# Altium schematic sheet BluePill.SchDoc
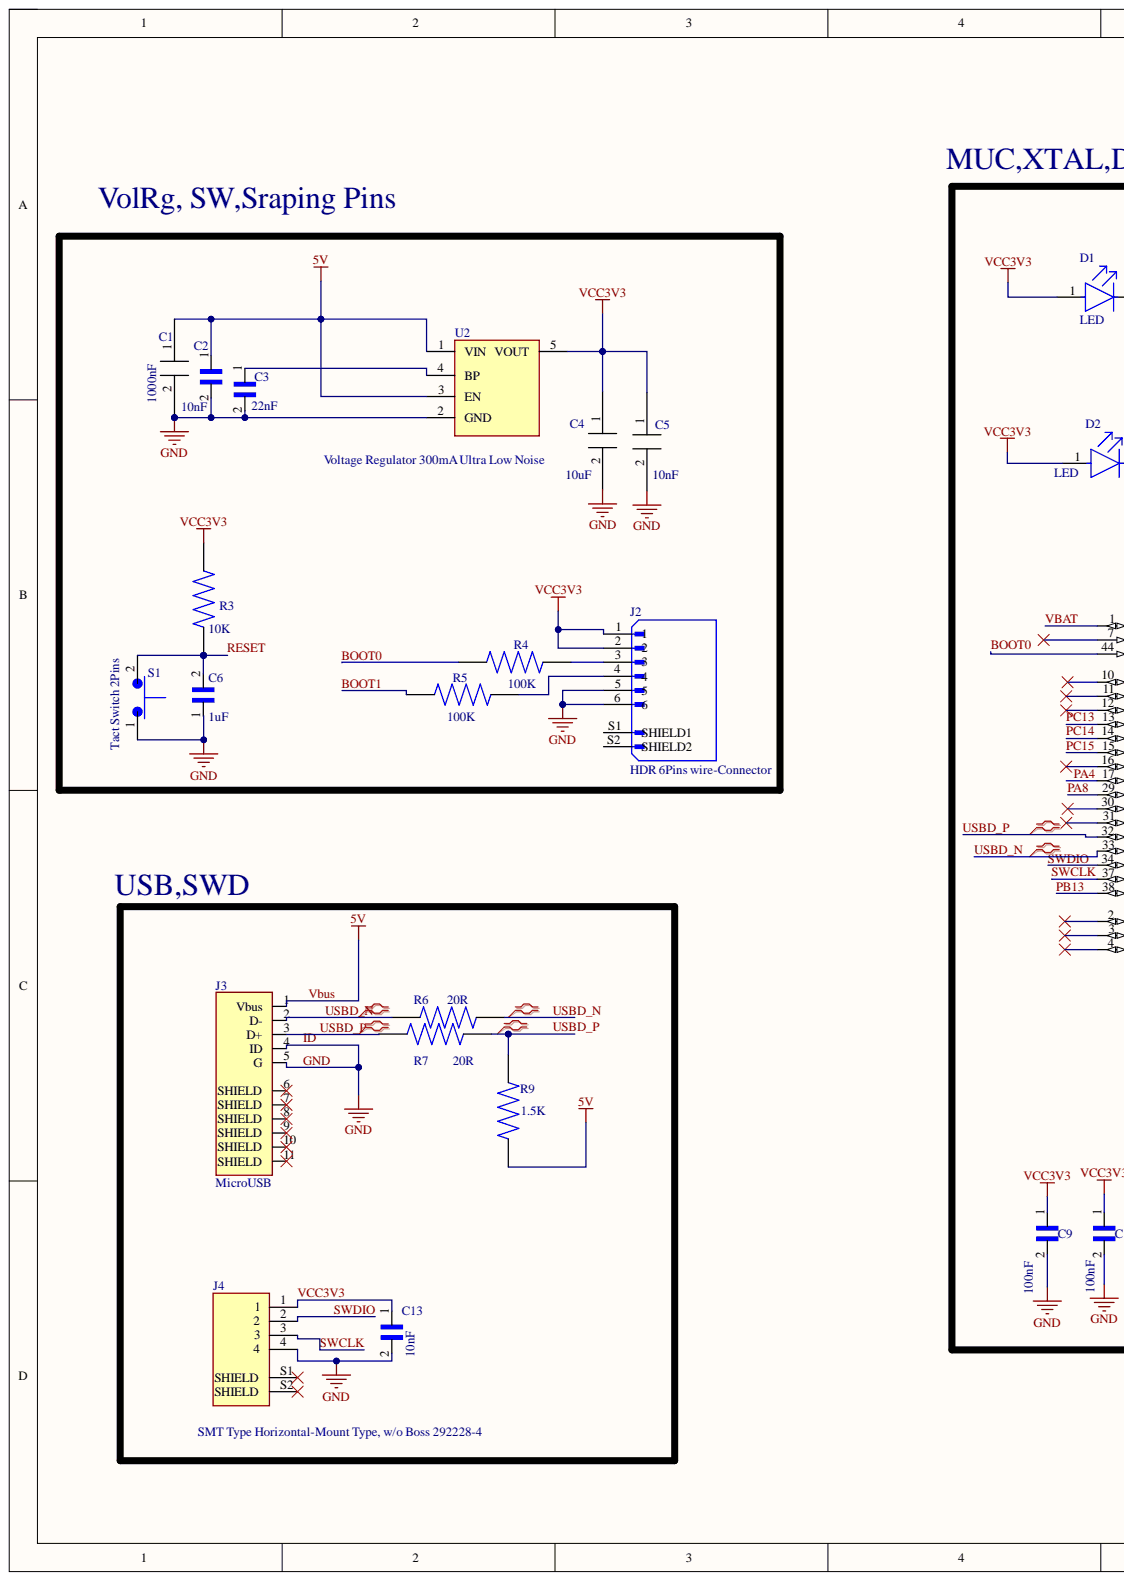
[diagram p0: BluePill.SchDoc, left]
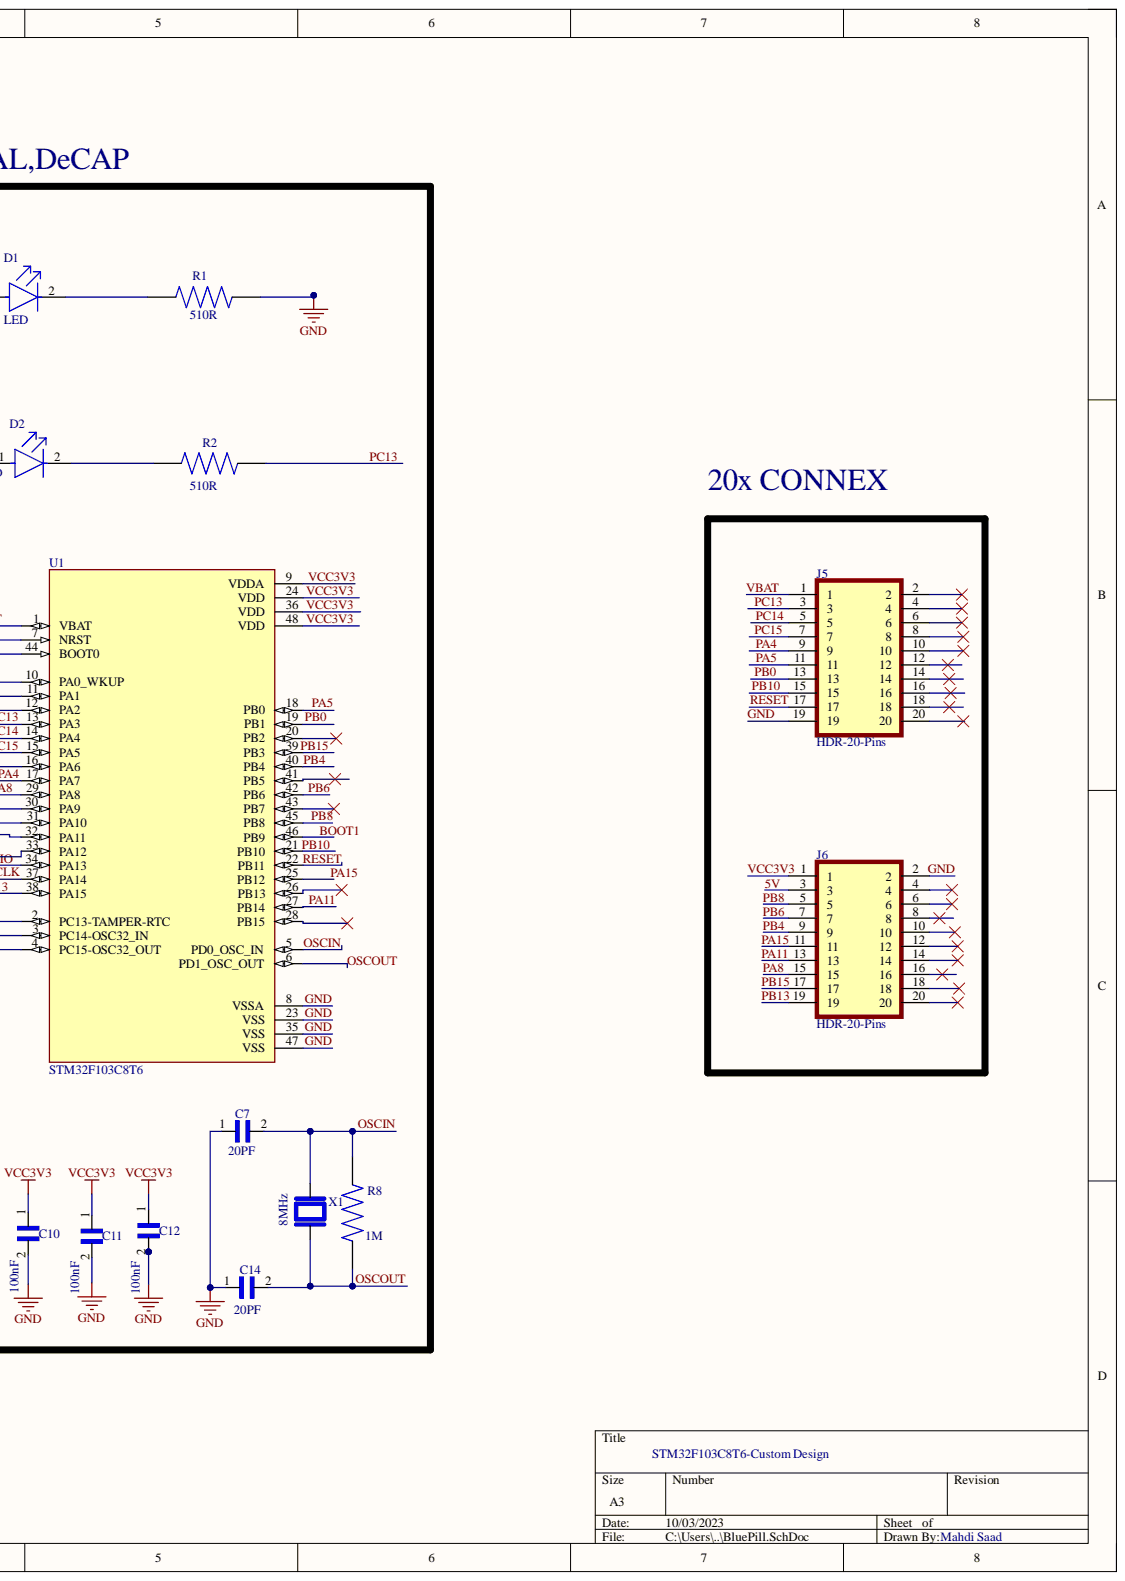
[diagram p1: BluePill.SchDoc, right]

## Parts
C1  1000nF  [Capacitor]
C2  10nF  [CAP_0603_Generic]
C3  22nF  [CAP_0603_Generic]
C4  10uF  [Capacitor]
C5  10nF  [Capacitor]
C6  1uF  [CAP_0603_Generic]
C7  20PF  [CAP_0603_Generic]
C9  100nF  [CAP_0603_Generic]
C10  100nF  [CAP_0603_Generic]
C11  100nF  [CAP_0603_Generic]
C12  100nF  [CAP_0603_Generic]
C13  10nF  [CAP_0603_Generic]
C14  20PF  [CAP_0603_Generic]
D1  LED  [LED 0508 Green SMT]
D2  LED  [LED 0508 Green SMT]
J2  HDR 6Pins wire-Connector  [6Pins HDR Wire-to-Board]
J3  MicroUSB  [MicroUSB]
J4  SMT Type Horizontal-Mount Type, w/o Boss 292228-4  [SMT 4 Pins Connector]
J5  HDR-20-Pins  [20Pins HDR]
J6  HDR-20-Pins  [20Pins HDR]
R1  510R  [Resisitor 0603 20 OHM]
R2  510R  [Resisitor 0603 20 OHM]
R3  10K  [Resisitor 0603 20 OHM]
R4  100K  [Resisitor 0603 20 OHM]
R5  100K  [Resisitor 0603 20 OHM]
R6  20R  [Resisitor 0603 20 OHM]
R7  20R  [Resisitor 0603 20 OHM]
R8  1M  [Capacitor]
R9  1.5K  [Resisitor 0603 20 OHM]
S1  Tact Switch 2Pins  [Tact Switch 2Pins]
U1  STM32F103C8T6  [STM32-New]
U2  Voltage Regulator 300mA Ultra Low Noise  [RT9193 Voltage Regulator]
X1  8MHz  [Crystal OSC 8Mhz]

## Nets
5V: C1.1 C2.1 J3.1(Vbus) J6.3 R9.1 U2.1(VIN) U2.3(EN)
BOOT0: R4.1 U1.44(BOOT0)
BOOT1: R5.1 U1.46(PB9)
GND: C1.2 C2.2 C3.2 C4.2 C5.2 C6.1 C7.1 C9.2 C10.2 C11.2 C12.2 C13.2 C14.1 J2.5 J2.6 J3.4(ID) J3.5(G) J4.4 J5.19 J6.2 R1.2 S1.1 U1.8(VSSA) U1.23(VSS) U1.35(VSS) U1.47(VSS) U2.2(GND)
OSCIN: C7.2 R8.2 U1.5(PD0_OSC_IN) X1.2
OSCOUT: C14.2 R8.1 U1.6(PD1_OSC_OUT) X1.1
PA4: J5.9 U1.17(PA7)
PA5: J5.11 U1.18(PB0)
PA8: J6.15 U1.29(PA8)
PA11: J6.13 U1.27(PB14)
PA15: J6.11 U1.25(PB12)
PB0: J5.13 U1.19(PB1)
PB4: J6.9 U1.40(PB4)
PB6: J6.7 U1.42(PB6)
PB8: J6.5 U1.45(PB8)
PB10: J5.15 U1.21(PB10)
PB13: J6.19 U1.38(PA15)
PB15: J6.17 U1.39(PB3)
PC13: J5.3 R2.2 U1.13(PA3)
PC14: J5.5 U1.14(PA4)
PC15: J5.7 U1.15(PA5)
RESET: C6.2 J5.17 R3.1 S1.2 U1.22(PB11)
SWCLK: J4.3 U1.37(PA14)
SWDIO: J4.2 U1.34(PA13)
USBD_N: J3.2(D-) R6.1 R6.2 U1.33(PA12)
USBD_P: J3.3(D+) R7.1 R7.2 R9.2 U1.32(PA11)
VBAT: J5.1 U1.1(VBAT)
VCC3V3: C4.1 C5.1 C9.1 C10.1 C11.1 C12.1 C13.1 D1.1 D2.1 J2.1 J2.2 J4.1 J6.1 R3.2 U1.9(VDDA) U1.24(VDD) U1.36(VDD) U1.48(VDD) U2.5(VOUT)
NetC3_1: C3.1 U2.4(BP)
NetD1_2: D1.2 R1.1
NetD2_2: D2.2 R2.1
NetJ2_3: J2.3 R4.2
NetJ2_4: J2.4 R5.2

Not connected: J2 S1(SHIELD1) S2(SHIELD2); J3 6(SHIELD) 7(SHIELD) 8(SHIELD) 9(SHIELD) 10(SHIELD) 11(SHIELD); J4 S1(SHIELD) S2(SHIELD); J5 2 4 6 8 10 12 14 16 18 20; J6 4 6 8 10 12 14 16 18 20; U1 2(PC13-TAMPER-RTC) 3(PC14-OSC32_IN) 4(PC15-OSC32_OUT) 7(NRST) 10(PA0_WKUP) 11(PA1) 12(PA2) 16(PA6) 20(PB2) 26(PB13) 28(PB15) 30(PA9) 31(PA10) 41(PB5) 43(PB7)

## Library data of the parts
C1 = Cap_0805_100nF: Component Kind: Standard; Description: 1000nF; Library Name: Integrated_Library_cheetah.IntLib
C2 = CAP_0603_Generic: 10nF Â±5% 16V Ceramic Capacitor X7R 0603 (1608 Metric); Component Kind: Standard; Library Name: Integrated_Library_cheetah.IntLib
C3 = CAP_0603_Generic: 22nF Â±5% 16V Ceramic Capacitor X7R 0603 (1608 Metric); Component Kind: Standard; Library Name: Integrated_Library_cheetah.IntLib
C4 = Cap_0805_100nF: 10uF C; Component Kind: Standard; Library Name: Integrated_Library_cheetah.IntLib
C5 = Cap_0805_100nF: 10 nF Global Cap; Component Kind: Standard; Library Name: Integrated_Library_cheetah.IntLib
C6 = CAP_0603_Generic: 1uF Â±5% 16V Ceramic Capacitor X7R 0603 (1608 Metric); Component Kind: Standard; Library Name: Integrated_Library_cheetah.IntLib
C7 C9-C14 = CAP_0603_Generic: 0.022 ÂµF Â±5% 16V Ceramic Capacitor X7R 0603 (1608 Metric); Component Kind: Standard; Library Name: Integrated_Library_cheetah.IntLib
D1 D2 = LED Green Wurth Elektronik 150080GS75000: WURTH ELEKTRONIK - 150080GS75000 - LED, Green, SMD, 0805, 30 mA, 3.2 V, 520 nm; Component Kind: Standard; Library Name: Integrated_Library_cheetah.IntLib
J2 = HDR 6Pins wire-Connector: Connector Header Surface Mount 6 position 0.049 (1.25mm); Component Kind: Standard; Library Name: Integrated_Library_cheetah.IntLib
J3 = MicroUSB: Micro USB  1981568-1  USB - micro B USB 2.0 Receptacle Connector 5 Position Surface Mount, Right Angle; Component Kind: Standard; Library Name: Integrated_Library_cheetah.IntLib
J4 = SMT Type Horizontal-Mount Type, w/o Boss 292228-4: Conn Shrouded Header HDR 4 POS 1.5mm Solder RA SMD Box/Tape; Component Kind: Standard; Library Name: Integrated_Library_cheetah.IntLib
R1-R7 R9 = Resistor 0603 20 OHM: RES SMD 20 OHM 0.1% 1/16W 0603; Component Kind: Standard; Library Name: Integrated_Library_cheetah.IntLib
R8 = Resistor D: Res Thin Film 0805 13K Ohm 0.1% 1/10W Â±10ppm/Â°C Molded SMD SMD Paper T/R; Component Kind: Standard; Library Name: Integrated_Library_cheetah.IntLib
S1 = Tact Switch 2Pins: actile Switch SPST-NO Top Actuated Surface Mount; Component Kind: Standard; Library Name: Integrated_Library_cheetah.IntLib
U1 = STM32 2nd-New: ARMÂ® CortexÂ®-M3 STM32F1 Microcontroller IC 32-Bit 72MHz 64KB (64K x 8) FLASH 48-LQFP (7x7); Component Kind: Standard; Library Name: Integrated_Library_cheetah.IntLib
U2 = Voltage Regulator 300mA Ultra Low Noise: Linear Voltage Regulator IC Positive Fixed 1 Output 300mA TSOT-23-5; Component Kind: Standard; Library Name: Integrated_Library_cheetah.IntLib
X1 = Crystal OSC 8Mhz: HC/49US (AT49) SMD LOW PROFILE CRYSTAL; Component Kind: Standard; Library Name: Integrated_Library_cheetah.IntLib
J5 J6 = HDR-20-Pins: Component Kind: Standard; Library Name: Integrated_Library_cheetah.IntLib
